# Altium schematic sheet Cpu.SchDoc(Cpu)
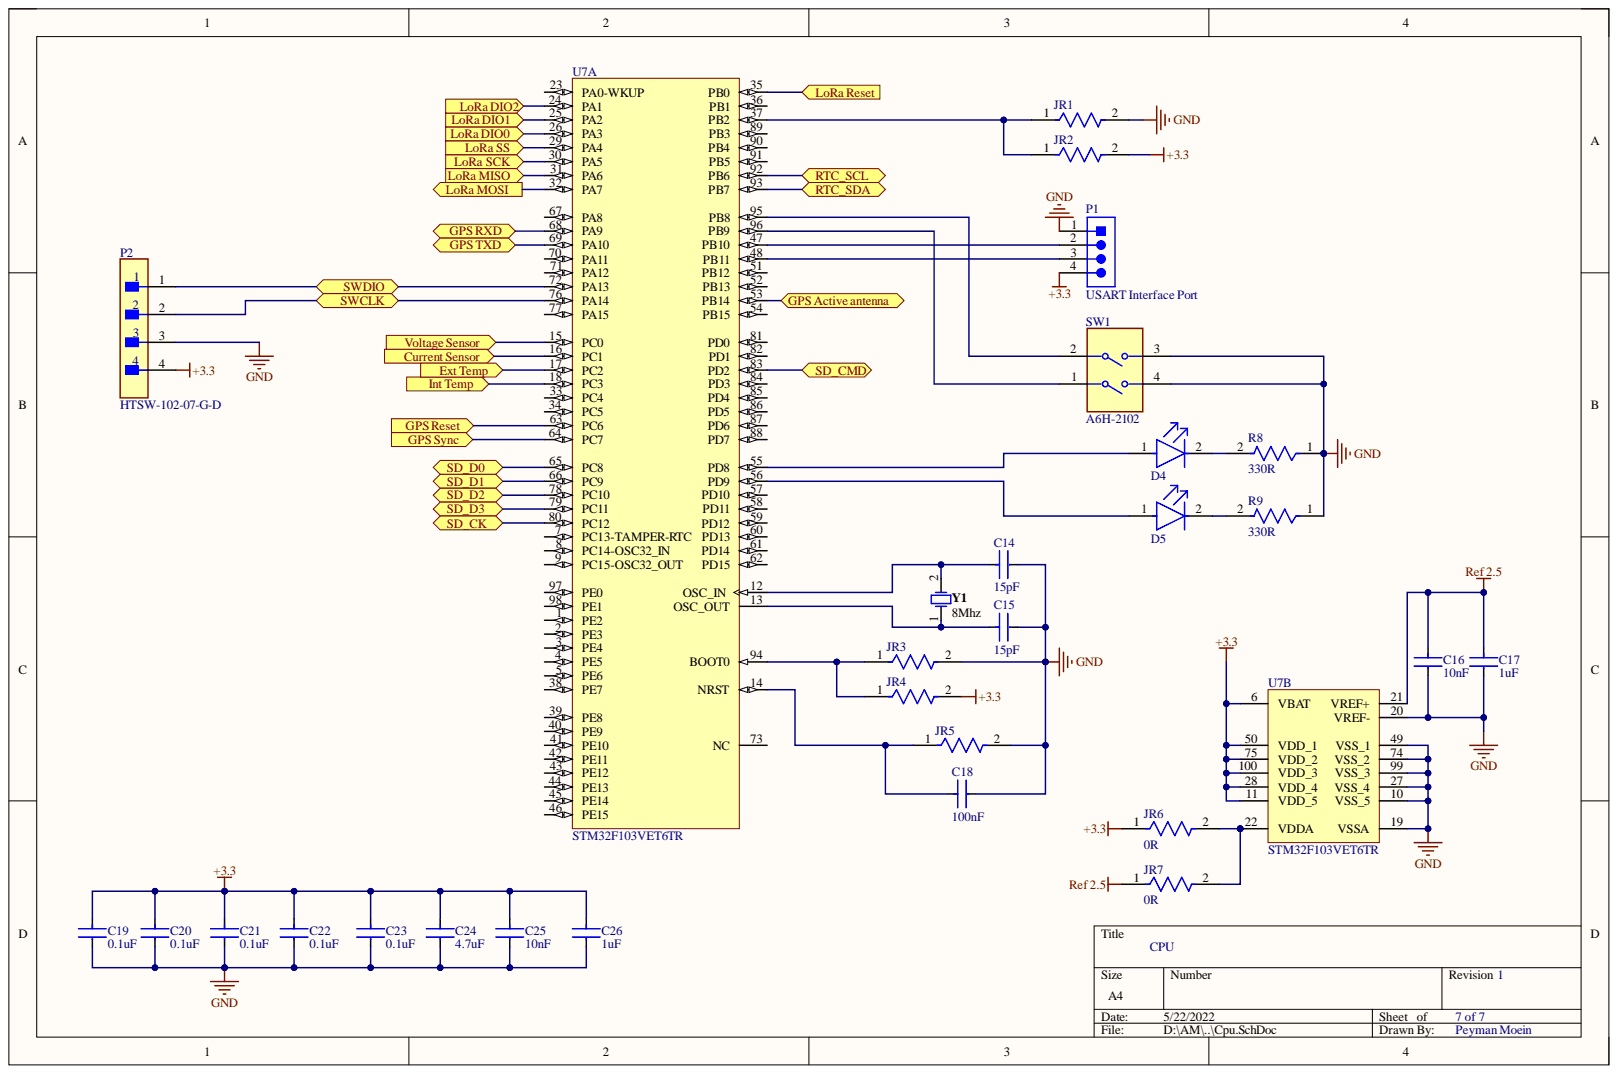
[diagram p0: Cpu.SchDoc]

## Parts
C14  15pF  [FP-C0805C-DN-MFG]
C15  15pF  [FP-C0805C-DN-MFG]
C16  10nF  [FP-C0805C-DN-MFG]
C17  1uF  [FP-C0805C-DN-MFG]
C18  100nF  [FP-C0805C-DN-MFG]
C19  0.1uF  [FP-C0805C-DN-MFG]
C20  0.1uF  [FP-C0805C-DN-MFG]
C21  0.1uF  [FP-C0805C-DN-MFG]
C22  0.1uF  [FP-C0805C-DN-MFG]
C23  0.1uF  [FP-C0805C-DN-MFG]
C24  4.7uF  [FP-C0805C-DN-MFG]
C25  10nF  [FP-C0805C-DN-MFG]
C26  1uF  [FP-C0805C-DN-MFG]
D4  APT2012MGC  [FP-APT2012MGC-MFG]  (value not drawn)
D5  APT2012MGC  [FP-APT2012MGC-MFG]  (value not drawn)
JR1  0R  [FP-RMCF0805-MFG]  (value not drawn)
JR2  0R  [FP-RMCF0805-MFG]  (value not drawn)
JR3  0R  [FP-RMCF0805-MFG]  (value not drawn)
JR4  0R  [FP-RMCF0805-MFG]  (value not drawn)
JR5  NC  [FP-RMCF0805-MFG]  (value not drawn)
JR6  0R  [FP-RMCF0805-MFG]
JR7  0R  [FP-RMCF0805-MFG]
P1  USART Interface Port  [JST-S4B-XH-A(LF)(SN)_V]
P2  HTSW-102-07-G-D  [FP-HTSW-102-07-G-D-MFG]
R8  330R  [FP-CRCW0805-e3-MFG]
R9  330R  [FP-CRCW0805-e3-MFG]
SW1  A6H-2102  [FP-A6H-2102-MFG]
U7  STM32F103VET6TR  [STM-LQFP100_L]  (parts A,B)
Y1  8Mhz  [FOX-FOXSLF080-20]

## Ports
Current Sensor, Ext Temp, GPS Active antenna, GPS Reset, GPS RXD, GPS Sync, GPS TXD, Int Temp, LoRa DIO0, LoRa DIO1, LoRa DIO2, LoRa MISO, LoRa MOSI, LoRa Reset, LoRa SCK, LoRa SS, RTC_SCL, RTC_SDA, SD_CK, SD_CMD, SD_D0, SD_D1, SD_D2, SD_D3, SWCLK, SWDIO, Voltage Sensor

## Nets
+3.3: C19.1 C20.1 C21.1 C22.1 C23.1 C24.1 C25.1 C26.1 JR2.2 JR4.2 JR6.1 P1.4 P2.4 U7.6(VBAT) U7.11(VDD_5) U7.28(VDD_4) U7.50(VDD_1) U7.75(VDD_2) U7.100(VDD_3)
GND: C14.2 C15.2 C16.2 C17.2 C18.2 C19.2 C20.2 C21.2 C22.2 C23.2 C24.2 C25.2 C26.2 JR1.2 JR3.2 JR5.2 P1.1 P2.3 R8.1 R9.1 SW1.3 SW1.4 U7.10(VSS_5) U7.19(VSSA) U7.20(VREF-) U7.27(VSS_4) U7.49(VSS_1) U7.74(VSS_2) U7.99(VSS_3)
Ref 2.5: C16.1 C17.1 JR7.1 U7.21(VREF+)
Current Sensor: U7.16(PC1) ; port Current Sensor
Ext Temp: U7.17(PC2) ; port Ext Temp
GPS Active antenna: U7.53(PB14) ; port GPS Active antenna
GPS Reset: U7.63(PC6) ; port GPS Reset
GPS RXD: U7.68(PA9) ; port GPS RXD
GPS Sync: U7.64(PC7) ; port GPS Sync
GPS TXD: U7.69(PA10) ; port GPS TXD
Int Temp: U7.18(PC3) ; port Int Temp
LoRa DIO0: U7.26(PA3) ; port LoRa DIO0
LoRa DIO1: U7.25(PA2) ; port LoRa DIO1
LoRa DIO2: U7.24(PA1) ; port LoRa DIO2
LoRa MISO: U7.31(PA6) ; port LoRa MISO
LoRa MOSI: U7.32(PA7) ; port LoRa MOSI
LoRa Reset: U7.35(PB0) ; port LoRa Reset
LoRa SCK: U7.30(PA5) ; port LoRa SCK
LoRa SS: U7.29(PA4) ; port LoRa SS
RTC_SCL: U7.92(PB6) ; port RTC_SCL
RTC_SDA: U7.93(PB7) ; port RTC_SDA
SD_CK: U7.80(PC12) ; port SD_CK
SD_CMD: U7.83(PD2) ; port SD_CMD
SD_D0: U7.65(PC8) ; port SD_D0
SD_D1: U7.66(PC9) ; port SD_D1
SD_D2: U7.78(PC10) ; port SD_D2
SD_D3: U7.79(PC11) ; port SD_D3
SWCLK: P2.2 U7.76(PA14) ; port SWCLK
SWDIO: P2.1 U7.72(PA13) ; port SWDIO
Voltage Sensor: U7.15(PC0) ; port Voltage Sensor
NetC14_1: C14.1 U7.12(OSC_IN) Y1.2
NetC15_1: C15.1 U7.13(OSC_OUT) Y1.1
NetC18_1: C18.1 JR5.1 U7.14(NRST)
NetD4_1: D4.1 U7.55(PD8)
NetD4_2: D4.2 R8.2
NetD5_1: D5.1 U7.56(PD9)
NetD5_2: D5.2 R9.2
NetJR1_1: JR1.1 JR2.1 U7.37(PB2)
NetJR3_1: JR3.1 JR4.1 U7.94(BOOT0)
NetJR6_2: JR6.2 JR7.2 U7.22(VDDA)
NetP1_2: P1.2 U7.47(PB10)
NetP1_3: P1.3 U7.48(PB11)
NetSW1_1: SW1.1 U7.96(PB9)
NetSW1_2: SW1.2 U7.95(PB8)

Not connected: U7 1(PE2) 2(PE3) 3(PE4) 4(PE5) 5(PE6) 7(PC13-TAMPER-RTC) 8(PC14-OSC32_IN) 9(PC15-OSC32_OUT) 23(PA0-WKUP) 33(PC4) 34(PC5) 36(PB1) 38(PE7) 39(PE8) 40(PE9) 41(PE10) 42(PE11) 43(PE12) 44(PE13) 45(PE14) 46(PE15) 51(PB12) 52(PB13) 54(PB15) 57(PD10) 58(PD11) 59(PD12) 60(PD13) 61(PD14) 62(PD15) 67(PA8) 70(PA11) 71(PA12) 73(NC) 77(PA15) 81(PD0) 82(PD1) 84(PD3) 85(PD4) 86(PD5) 87(PD6) 88(PD7) 89(PB3) 90(PB4) 91(PB5) 97(PE0) 98(PE1)

## Library data of the parts
C14-C26 = CMP-2007-00279-2: CAP CER 0.1UF 50V X7R 0805; Manufacturer: KEMET; Manufacturer Part Number: C0805C104K5RACTU; Capacitance: 0.10 μF; Material: Ceramic; Temperature Coefficient: 30ppm/°C; Tolerance: 0.1; Value: 0.10 μF; Automotive: No; Category: Cap; Component Kind: Standard; ComponentLink2Description: Datasheet; ComponentLink2URL: https://content.kemet.com/datasheets/KEM_C1003_C0G_SMD.pdf; Device Class L1: Passive Components; Device Class L2: Capacitors; Device Class L3: Ceramic Capacitors; Digikey Description: CAP CER 0.1UF 50V X7R 0805; Height: 0.88mm; Ipc Land Pattern Name: CAPC200125X78; Lead Free: Yes; Library Name: Altium Content Vault; Mouser Description: Cap Ceramic 0.1uF 50V X7R 10% SMD 2.0 x 1.2 mm 125 C Paper T/R; Octopart: https://octopart.com/c0805c104k5ractu-kemet-143926; Package: C0805C-DN; RoHS: TRUE; Temperature: -55°C to +125°C; Temperature Characteristic: X7R; Temperature Range High: +125°C; Temperature Range Low: -55°C; Voltage Rating: 50 V
D4 D5 = CMP-44529-000017-1: LED GREEN CLEAR CHIP SMD; Dominant Wavelength: 570nm; Forward Current: 20mA; Forward Voltage: 2.1V; Lens Color: Colorless; Luminous Intensity: 70mcd; Power Rating: 75mW; Viewing Angle: 120°; Case Code (Imperial): 0805; Case Code (Metric): 2012; Case/Package: 0805; Color: Green; Component Kind: Standard; Height: 0.75mm; Illumination Color: Green; Lead Free: Lead Free; Length: 2mm; Lens Style: Clear; Lens Transparency: Clear; Library Name: Altium Content Vault; Max Operating Temperature: 85°C; Min Operating Temperature: -40°C; Mount: Surface Mount; Package Quantity: 2000; Packaging: Tape and Reel; Test Current: 20mA; Width: 1.25mm
JR1-JR7 = CMP-26527-000017-1: RES 0 OHM JUMPER 1/8W 0805; Max Power Dissipation: 125mW; Resistance: 0mR; Case Code (Imperial): 0805; Case Code (Metric): 2012; Case/Package: 0805; Component Kind: Standard; Composition: Thick Film; Contact Plating: Tin; Depth: 1.25mm; Features: Automotive AEC-Q200; Height: 0.026inch; Lead Free: Lead Free; Length: 2mm; Library Name: Altium Content Vault; Max Operating Temperature: 155°C; Military Standard: Not; Min Operating Temperature: -55°C; Mount: Surface Mount; Number of Terminations: 2; Packaging: Tape and Reel; Power Rating: 125mW; Radiation Hardening: No; Width: 0.049inch
P1 = CMP-1755-00007-1: Component Kind: Standard; ComponentLink1Description: Manufacturer URL; ComponentLink1URL: http://www.jst.com/home2.html; ComponentLink2Description: Datasheet; ComponentLink2URL: http://www.jst-mfg.com/product/pdf/eng/eXH.pdf; DatasheetVersion: May-16; Library Name: Altium Content Vault; PackageDescription: Male Header, Pitch 2.5 mm, 1 x 4 Position, Height 6.1 mm, Tail Length 3.4 mm; PackageReference: S4B-XH-A(LF)(SN)
P2 = CMP-02766-000010-1: CONN HEADER VERT 4POS 2.54MM; Manufacturer: Samtec; Conn Gender: Male; Conn Orientation: Straight; Current Rating: 6.3A; Number Of Contacts: 4; Voltage Rating: 550V; Automotive: No; Category: Conn; Component Kind: Standard; ComponentLink2Description: Datasheet; ComponentLink2URL: http://suddendocs.samtec.com/catalog_english/htsw_th.pdf; Contact Material: Gold,Nickel; Device Class L1: Connectors; Device Class L2: Headers and Wire Housings; Device Class L3: unset; Digikey Description: CONN HEADER VERT 4POS 2.54MM; Height: 8.71mm; Lead Free: Yes; Library Name: Altium Content Vault; Manufacturer Part Number: HTSW-102-07-G-D; Mouser Description: Conn Unshrouded Header HDR 4 POS 2.54mm Solder ST Thru-Hole Bag; Number Of Rows: 2; Octopart: https://octopart.com/htsw-102-07-g-d-samtec-10531767; Package: HTSW-102-07-G-D; Pitch: 2.54mm; RoHS: TRUE; Temperature: -55°C to +125°C; Temperature Range High: +125°C; Temperature Range Low: -55°C
R8 R9 = CMP-02407-004193-1: RES Thick Film, 2.94Ω, 1%, 0.125W, 100ppm/°C, 0805; Max Power Dissipation: 125mW; Resistance: 2.94R; Voltage Rating: 150V; Case Code (Imperial): 0805; Case Code (Metric): 2012; Case/Package: 0805; Component Kind: Standard; Composition: Thick Film; Contact Plating: Tin; Depth: 1.25mm; Features: Automotive AEC-Q200; Height: 0.02inch; Lead Free: Lead Free; Length: 2mm; Library Name: Altium Content Vault; Max Operating Temperature: 155°C; Military Standard: Not; Min Operating Temperature: -55°C; Number of Terminations: 2; Package Quantity: 10; Packaging: Tape and Reel; Power Rating: 125mW; Radiation Hardening: No; Temperature Coefficient: 100ppm/°C; Termination: SMD/SMT; Tolerance: 1%; Weight: 0.000194oz; Width: 0.049inch
SW1 = CMP-08827-000068-1: SWITCH SLIDE DIP SPST 25MA 24V; Actuator Length: 0mm; Actuator Type: Slide (Standard); Contact Resistance: 200mR; Electrical Life: 1000Cycles; Number of Circuits: 2; Number of Poles: 8; Pitch: 0.05inch; Washable: Yes; Case/Package: DIP; Component Kind: Standard; Contact Current Rating: 25mA; Current Rating: 25mA; Height: 1.55mm; Length: 0.148inch; Library Name: Altium Content Vault; Max Current Rating: 25mA; Max Operating Temperature: 70°C; Max Voltage Rating (DC): 24V; Mechanical Life: 1000Cycles; Min Operating Temperature: -20°C; Mount: Surface Mount; Number of Positions: 2; Orientation: Straight; Package Quantity: 75; Packaging: Rail/Tube; RoHS Compliant: Yes; Series: A6H; Terminal Pitch: 1.27mm; Termination: Gull Wing; Throw Configuration: SPST; Voltage Rating (DC): 24V; Weight: 0.06g; Width: 4.5mm
U7 = CMP-0237-00166-3: ARM Cortex-M3 32-bit MCU, 512 KB Flash, 64 KB Internal RAM, 80 I/Os, 100-pin LQFP, -40 to 85 degC, Tape and Reel; A/D Converter: 3x12-bit (16 Channel); D/A Converter: 2x12-bit (2 Channel); FLASH Size (Prog) (kB): 512; I/Os (High Current): 80; Internal RAM Size (kB): 64; Operating Frequency(F) (Processor speed) (MHz): 72; Supply Voltage(Vcc) min (V): 2; Component Kind: Standard; ComponentLink1Description: Manufacturer URL; ComponentLink1URL: http://www.st.com/web/en/home.html; ComponentLink2Description: Datasheet; ComponentLink2URL: http://www.st.com/st-web-ui/static/active/en/resource/technical/document/datasheet/CD00191185.pdf; ComponentLink3Description: Package Specification; ComponentLink3URL: http://www.st.com/st-web-ui/static/active/en/resource/technical/document/data_brief/DM00071938.pdf; Core: ARM Cortex-M3; DatasheetVersion: Rev. 8; Library Name: Altium Content Vault; Mounting Technology: Surface Mount; PackageDescription: 100-Pin Low Profile Quad Flat Package, 14 x 14 mm Body, 0.5 mm Pitch; PackageReference: LQFP100; PackageVersion: Rev. 1, 06/2013; Packing: Tape and Reel; PartNumber: STM32F103VET6TR
Y1 = CMP-1749-00002-1: Resistance Weld Thru-Hole Crystal, 8 MHz, -20 to 70 degC, 2-Pin THD, RoHS, Bulk; Case\Package: TO-263-3; Dropout Voltage: 2 V; Max Input Voltage: 35 V; Max Ouput Current: 1 A; Min Input Voltage: 7 V; Nominal Output Voltage: 5 V; Outputs: 1; Component Kind: Standard; ComponentLink1Description: Manufacturer URL; ComponentLink1URL: http://www.foxonline.com/; ComponentLink2Description: Datasheet; ComponentLink2URL: http://download.siliconexpert.com/pdfs/2015/4/5/6/5/7/200/fox_/manual/35607121449963728hc49slf.pdf; DatasheetVersion: Mar-15; Height: 30.02 mm; Length: 10.24 mm; Library Name: Altium Content Vault; Max Operating Temperature: 125 degC; Min Operating Temperature: 0 degC; Mount: Surface Mount; Output Current: 1 A; Output Voltage: 5 V; Package Quantity: 50; PackageDescription: 2-Pin Through Hole Device, Body 11.35 x 4.65 mm; PackageReference: FOXSLF080-20; Packaging: Rail/Tube; Pins: 3; Radiation Hardening: No; REACH SVHC: No SVHC; RoHSCompliant: true; Width: 9.35 mm
